# Altium schematic sheet Interfaces.SchDoc(Interfaces)
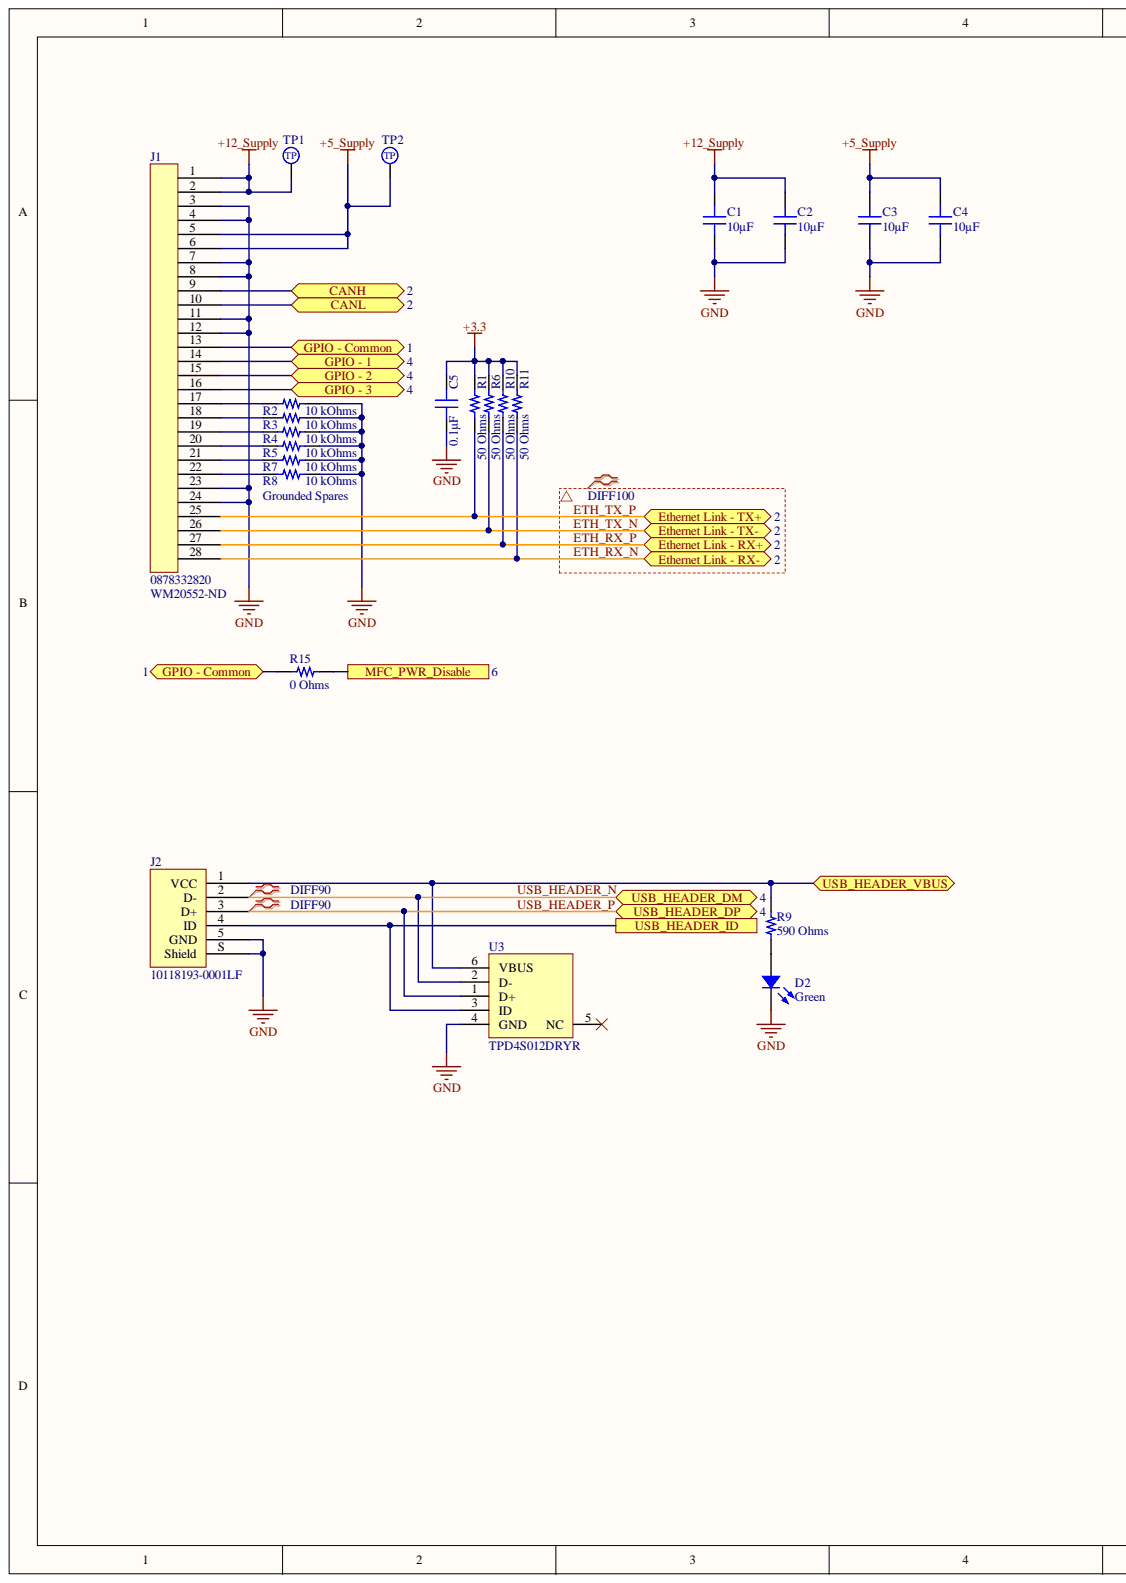
[diagram p0: Interfaces.SchDoc, left]
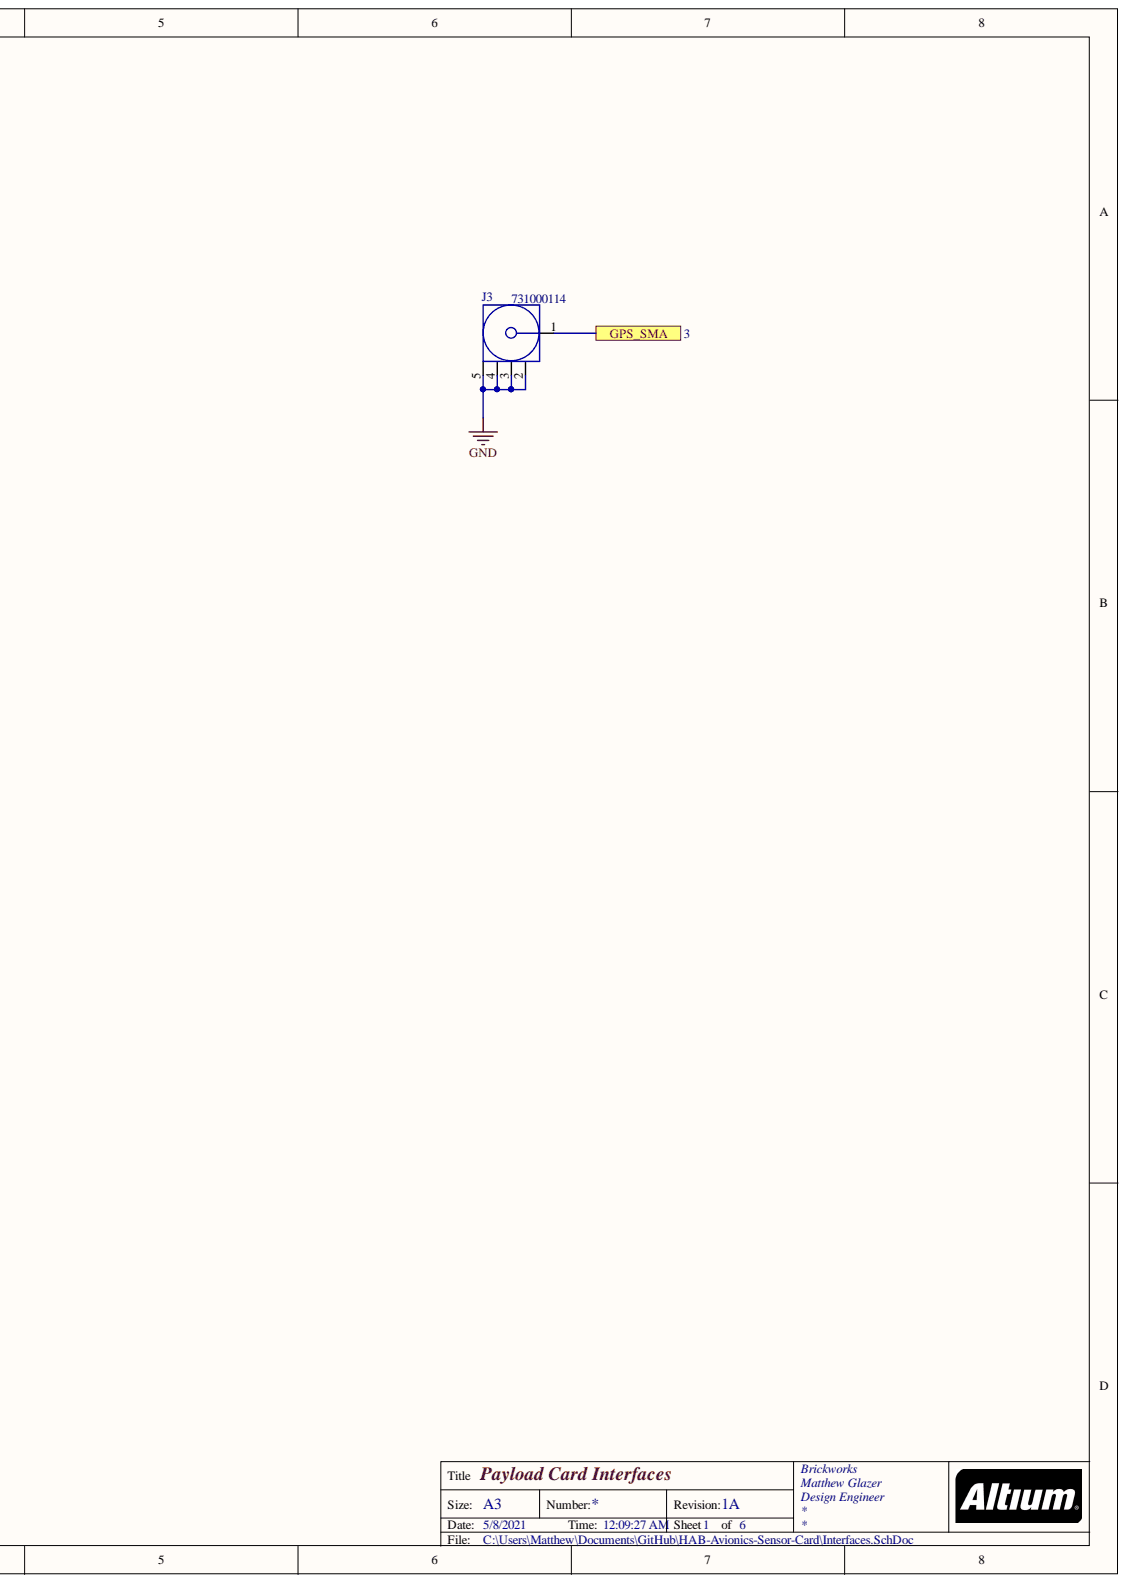
[diagram p1: Interfaces.SchDoc, right]

## Parts
C1  10µF  [CAP 0805_2012]
C2  10µF  [CAP 0805_2012]
C3  10µF  [CAP 0805_2012]
C4  10µF  [CAP 0805_2012]
C5  0.1µF  [CAP 0603_1608]
D2  Green  [LED 0603_1608 GREEN]
J1  0878332820  Supplier Part Number 1: WM20552-ND  [0878332820]
J2  10118193-0001LF  [FCI USB 10118193-0001LF]
J3  731000114  [MOLX-731000114_V]
R1  50 Ohms  [RES 0603_1608]
R2  10 kOhms  [RES 0603_1608]
R3  10 kOhms  [RES 0603_1608]
R4  10 kOhms  [RES 0603_1608]
R5  10 kOhms  [RES 0603_1608]
R6  50 Ohms  [RES 0603_1608]
R7  10 kOhms  [RES 0603_1608]
R8  10 kOhms  [RES 0603_1608]
R9  590 Ohms  [RES 0603_1608]
R10  50 Ohms  [RES 0603_1608]
R11  50 Ohms  [RES 0603_1608]
R15  0 Ohms  [RES 0603_1608]
TP1  2.5mm_SMD_TestPoint  [2.5mm TestPoint]  (value not drawn)
TP2  2.5mm_SMD_TestPoint  [2.5mm TestPoint]  (value not drawn)
U3  TPD4S012DRYR  [DRY0006A]

## Ports
CANH, CANL, Ethernet Link - RX+, Ethernet Link - RX-, Ethernet Link - TX+, Ethernet Link - TX-, GPIO - 1, GPIO - 2, GPIO - 3, GPIO - Common, GPS_SMA, MFC_PWR_Disable, USB_HEADER_DM, USB_HEADER_DP, USB_HEADER_ID, USB_HEADER_VBUS

## Nets
+3.3: C5.2 R1.2 R6.2 R10.2 R11.2
+5_Supply: C3.2 C4.2 J1.5 J1.6 TP2.1
+12_Supply: C1.2 C2.2 J1.1 J1.2 TP1.1
GND: C1.1 C2.1 C3.1 C4.1 C5.1 D2.2 J1.3 J1.4 J1.7 J1.8 J1.11 J1.12 J1.23 J1.24 J2.5(GND) J2.S(Shield) J3.2 J3.3 J3.4 J3.5 R2.2 R3.2 R4.2 R5.2 R7.2 R8.2 U3.4(GND)
CANH: J1.9 ; port CANH
CANL: J1.10 ; port CANL
ETH_RX_N: J1.28 R11.1
ETH_RX_P: J1.27 R10.1
ETH_TX_N: J1.26 R6.1
ETH_TX_P: J1.25 R1.1
GPIO - 1: J1.14 ; port GPIO - 1
GPIO - 2: J1.15 ; port GPIO - 2
GPIO - 3: J1.16 ; port GPIO - 3
GPIO - Common: J1.13 R15.1 ; port GPIO - Common
GPS_SMA: J3.1 ; port GPS_SMA
MFC_PWR_Disable: R15.2 ; port MFC_PWR_Disable
USB_HEADER_ID: J2.4(ID) U3.3(ID) ; port USB_HEADER_ID
USB_HEADER_N: J2.2(D-) U3.2(D-) ; port USB_HEADER_DM
USB_HEADER_P: J2.3(D+) U3.1(D+) ; port USB_HEADER_DP
USB_HEADER_VBUS: J2.1(VCC) R9.2 U3.6(VBUS) ; port USB_HEADER_VBUS
NetD2_1: D2.1 R9.1
NetJ1_17: J1.17 R2.1
NetJ1_18: J1.18 R3.1
NetJ1_19: J1.19 R4.1
NetJ1_20: J1.20 R5.1
NetJ1_21: J1.21 R7.1
NetJ1_22: J1.22 R8.1
N00023:

Not connected: U3 5(NC)

## Library data of the parts
C1-C4 = CC0805KKX5R7BB106: CAP CER 10UF 16V X5R 0805; Manufacturer: Yageo; Tolerance: ±10%; Database Table Name: Capacitors - Ceramic - 0805; Voltage - Rated: 16V; Value: 10µF; Thickness (Max): 0.057" (1.45mm); Temperature Coefficient: X5R; Supplier Part Number 1: 311-1865-1-ND; Supplier 1: DigiKey; Size / Dimension: 0.079" L x 0.049" W (2.00mm x 1.25mm); Series: CC; Price: 0.09; Part Status: Active; Part Number: CC0805KKX5R7BB106; PartId: 8867; Packaging: TapeAndReel; Package / Case: 0805 (2012 Metric); Operating Temperature: -55°C ~ 85°C; Mounting Type: Surface Mount, MLCC; Minimum Order: 1; Lifecycle Status: Active; Library Name: Celestial Altium Library - altium_library.DbLib; LastUpdated: 9/2/2020 1:04:30 AM; Device Package: 0805 (2012 Metric); ComponentLink2URL: http://digikey.com/product-detail/en/yageo/CC0805KKX5R7BB106/311-1865-1-ND/5195767; ComponentLink2Description: DigiKey Link; ComponentLink1URL: https://www.yageo.com/upload/media/product/productsearch/datasheet/mlcc/UPY-GPHC_X5R_4V-to-50V_25.pdf; ComponentLink1Description: Datasheet; Component Kind: Standard; Capacitance: 10µF; Applications: General Purpose
C5 = CL10B104KA8WPNC: CAP CER 0.1UF 25V X7R 0603; Manufacturer: Samsung Electro-Mechanics; Tolerance: ±10%; Database Table Name: Capacitors - Ceramic - 0603; Voltage - Rated: 25V; Value: 0.1µF; Thickness (Max): 0.035" (0.90mm); Temperature Coefficient: X7R; Supplier Part Number 1: 1276-6717-1-ND; Supplier 1: DigiKey; Size / Dimension: 0.063" L x 0.031" W (1.60mm x 0.80mm); Series: CL; Ratings: AEC-Q200; Price: 0.02; Part Status: Active; Part Number: CL10B104KA8WPNC; PartId: 5631; Packaging: TapeAndReel; Package / Case: 0603 (1608 Metric); Operating Temperature: -55°C ~ 125°C; Mounting Type: Surface Mount, MLCC; Minimum Order: 1; Lifecycle Status: Active; Library Name: Celestial Altium Library - altium_library.DbLib; LastUpdated: 9/2/2020 1:03:30 AM; Features: Open Mode; Device Package: 0603 (1608 Metric); ComponentLink2URL: http://digikey.com/product-detail/en/samsung-electro-mechanics/CL10B104KA8WPNC/1276-6717-1-ND/5961576; ComponentLink2Description: DigiKey Link; ComponentLink1URL: http://www.samsungsem.com/kr/support/product-search/mlcc/CL10B104KA8WPNC.jsp; ComponentLink1Description: Datasheet; Component Kind: Standard; Capacitance: 0.1µF; Applications: Automotive
D2 = LTST-C193KGKT-5A: LED GREEN CLEAR CHIP SMD; Manufacturer: Lite-On Inc.; Wavelength - Peak: 574nm; Wavelength - Dominant: 572nm; Voltage - Forward (Vf) (Typ): 2V; Viewing Angle: 130°; Millicandela Rating: 16.25mcd; Lens Style: Rectangle with Flat Top; Lens Size: 1.15mm x 0.80mm; Lens Color: Colorless; Database Table Name: LED - Indication; Current - Test: 5mA; Configuration: Standard; Value: Green; Supplier Part Number 1: 160-1828-1-ND; Supplier Device Package: Chip LED; Supplier 1: DigiKey; Size / Dimension: 1.60mm L x 0.80mm W; Series: -; Price: 0.06; Part Status: Active; Part Number: LTST-C193KGKT-5A; PartId: 694; Packaging: TapeAndReel; Package / Case: 0603 (1608 Metric); Mounting Type: Surface Mount; Minimum Order: 1; Lifecycle Status: Active; Library Name: Celestial Altium Library - altium_library.DbLib; Lens Transparency: Clear; LastUpdated: 9/2/2020 1:20:41 AM; Height (Max): 0.45mm; Device Package: 0603 (1608 Metric); ComponentLink2URL: http://digikey.com/product-detail/en/lite-on-inc/LTST-C193KGKT-5A/160-1828-1-ND/2356247; ComponentLink2Description: DigiKey Link; ComponentLink1URL: http://optoelectronics.liteon.com/upload/download/DS22-2007-0094/LTST-C193KGKT-5A.PDF; ComponentLink1Description: Datasheet; Component Kind: Standard; Color: Green
J2 = 10118193-0001LF: CONN RCPT USB2.0 MICRO B SMD R/A; Manufacturer: Amphenol ICC (FCI); Voltage - Rated: 100VAC; Shielding: Shielded; Number of Ports: 1; Number of Contacts: 5; Mating Cycles: 10000; Gender: Receptacle; Database Table Name: Connectors - USB DVI HDMI; Current Rating (Amps): 1.8A per Contact; Connector Type: USB - micro B; Termination: Solder; Supplier Part Number 1: 609-4616-2-ND; Supplier 1: DigiKey; Specifications: USB 2.0; Series: -; Price: 0.21; Part Status: Active; Part Number: 10118193-0001LF; Packaging: TapeAndReel; Operating Temperature: -30°C ~ 80°C; Mounting Type: Surface Mount, Right Angle; Through Hole; Mounting Feature: Horizontal; Minimum Order: 1; Lifecycle Status: 0; Library Name: Celestial Altium Library - altium_library.DbLib; LastUpdated: 12/9/2019 8:51:28 PM; Features: Solder Retention; ComponentLink2URL: //digikey.com/product-detail/en/amphenol-icc-fci/10118193-0001LF/609-4616-2-ND/2785388; ComponentLink2Description: DigiKey Link; ComponentLink1URL: https://cdn.amphenol-icc.com/media/wysiwyg/files/documentation/datasheet/inputoutput/io_usb_micro.pdf; ComponentLink1Description: Datasheet; Component Kind: Standard
J3 = CMP-2000-05487-1: SMA Right-Angle PCB Jack Receptacle, Brass Body, Gold Plated, 50 Ohm, 5-Pin THD, RoHS, Tray; Manufacturer: Molex; Package URL: http://www.molex.com/pdm_docs/sd/731000114_sd.pdf; Package Reference: 731000114; Package Description: 5-Pin Through Hole Device, Body 14.8 x 6.35 mm, Pitch 2.54 mm; Mounting Technology: ThroughHole; Manufacturer URL: http://www.molex.com/; Library Name: Altium Content Vault; Datasheet Version: 09/2016; Datasheet URL: http://www.molex.com/webdocs/datasheets/pdf/en-us/0731000114_RF_COAX_CONNECTORS.pdf; Component Kind: Standard
R1 R6 R10 R11 = CRCW060350R0FKEA: RES SMD 50 OHM 1% 1/10W 0603; Manufacturer: Vishay Dale; Temperature Coefficient: ±100ppm/°C; Power (Watts): 0.1W, 1/10W; Database Table Name: Resistors - Surface Mount - 0603; Value: 50 Ohms; Tolerance: ±1%; Supplier Part Number 1: 541-3318-1-ND; Supplier Device Package: 0603; Supplier 1: DigiKey; Size / Dimension: 0.061" L x 0.033" W (1.55mm x 0.85mm); Series: CRCW; Resistance: 50 Ohms; Ratings: AEC-Q200; Price: 0.10; Part Status: Active; Part Number: CRCW060350R0FKEA; PartId: 23780; Packaging: CutTape; Package / Case: 0603 (1608 Metric); Operating Temperature: -55°C ~ 155°C; Number of Terminations: 2; Minimum Order: 1; Lifecycle Status: Active; Library Name: Celestial Altium Library - altium_library.DbLib; LastUpdated: 9/2/2020 1:09:40 AM; Height - Seated (Max): 0.020" (0.50mm); Features: Automotive AEC-Q200; Device Package: 0603 (1608 Metric); Composition: Thick Film; ComponentLink2URL: http://digikey.com/product-detail/en/vishay-dale/CRCW060350R0FKEA/541-3318-1-ND/6684924; ComponentLink2Description: DigiKey Link; ComponentLink1URL: http://www.vishay.com/docs/20035/dcrcwe3.pdf; ComponentLink1Description: Datasheet; Component Kind: Standard
R2-R5 R7 R8 = RMCF0603JT10K0: RES 10K OHM 5% 1/10W 0603; Manufacturer: Stackpole Electronics Inc; Temperature Coefficient: ±200ppm/°C; Power (Watts): 0.1W, 1/10W; Database Table Name: Resistors - Surface Mount - 0603; Value: 10 kOhms; Tolerance: ±5%; Supplier Part Number 1: RMCF0603JT10K0CT-ND; Supplier Device Package: 0603; Supplier 1: DigiKey; Size / Dimension: 0.061" L x 0.031" W (1.55mm x 0.80mm); Series: RMCF; Resistance: 10 kOhms; Ratings: AEC-Q200; Price: 0.10; Part Status: Active; Part Number: RMCF0603JT10K0; PartId: 25659; Packaging: CutTape; Package / Case: 0603 (1608 Metric); Operating Temperature: -55°C ~ 155°C; Number of Terminations: 2; Minimum Order: 1; Lifecycle Status: Active; Library Name: Celestial Altium Library - altium_library.DbLib; LastUpdated: 8/30/2020 8:48:45 PM; Height - Seated (Max): 0.022" (0.55mm); Features: Automotive AEC-Q200; Device Package: 0603 (1608 Metric); Composition: Thick Film; ComponentLink2URL: http://digikey.com/product-detail/en/stackpole-electronics-inc/RMCF0603JT10K0/RMCF0603JT10K0CT-ND/1943191; ComponentLink2Description: DigiKey Link; ComponentLink1URL: https://www.seielect.com/catalog/sei-packaging.pdf; ComponentLink1Description: Datasheet; Component Kind: Standard
R9 = RMCF0603FT590R: RES 590 OHM 1% 1/10W 0603; Manufacturer: Stackpole Electronics Inc; Temperature Coefficient: ±100ppm/°C; Power (Watts): 0.1W, 1/10W; Database Table Name: Resistors - Surface Mount - 0603; Value: 590 Ohms; Tolerance: ±1%; Supplier Part Number 1: RMCF0603FT590RCT-ND; Supplier Device Package: 0603; Supplier 1: DigiKey; Size / Dimension: 0.061" L x 0.031" W (1.55mm x 0.80mm); Series: RMCF; Resistance: 590 Ohms; Ratings: AEC-Q200; Price: 0.10; Part Status: Active; Part Number: RMCF0603FT590R; PartId: 23847; Packaging: CutTape; Package / Case: 0603 (1608 Metric); Operating Temperature: -55°C ~ 155°C; Number of Terminations: 2; Minimum Order: 1; Lifecycle Status: Active; Library Name: Celestial Altium Library - altium_library.DbLib; LastUpdated: 9/2/2020 1:09:40 AM; Height - Seated (Max): 0.022" (0.55mm); Features: Automotive AEC-Q200; Device Package: 0603 (1608 Metric); Composition: Thick Film; ComponentLink2URL: http://digikey.com/product-detail/en/stackpole-electronics-inc/RMCF0603FT590R/RMCF0603FT590RCT-ND/2418096; ComponentLink2Description: DigiKey Link; ComponentLink1URL: https://www.seielect.com/catalog/sei-rmcf_rmcp.pdf; ComponentLink1Description: Datasheet; Component Kind: Standard
R15 = RMCF0603ZT0R00: RES 0 OHM JUMPER 1/10W 0603; Manufacturer: Stackpole Electronics Inc; Power (Watts): 0.1W, 1/10W; Database Table Name: Resistors - Surface Mount - 0603; Value: 0 Ohms; Tolerance: Jumper; Supplier Part Number 1: RMCF0603ZT0R00CT-ND; Supplier Device Package: 0603; Supplier 1: DigiKey; Size / Dimension: 0.061" L x 0.031" W (1.55mm x 0.80mm); Series: RMCF; Resistance: 0 Ohms; Ratings: AEC-Q200; Price: 0.10; Part Status: Active; Part Number: RMCF0603ZT0R00; PartId: 22613; Packaging: CutTape; Package / Case: 0603 (1608 Metric); Operating Temperature: -55°C ~ 155°C; Number of Terminations: 2; Minimum Order: 1; Lifecycle Status: Active; Library Name: Celestial Altium Library - altium_library.DbLib; LastUpdated: 9/2/2020 1:09:45 AM; Height - Seated (Max): 0.022" (0.55mm); Features: Automotive AEC-Q200; Device Package: 0603 (1608 Metric); Composition: Thick Film; ComponentLink2URL: http://digikey.com/product-detail/en/stackpole-electronics-inc/RMCF0603ZT0R00/RMCF0603ZT0R00CT-ND/1943218; ComponentLink2Description: DigiKey Link; ComponentLink1URL: https://www.seielect.com/catalog/sei-rmcf_rmcp.pdf; ComponentLink1Description: Datasheet; Component Kind: Standard
U3 = CMP-0326-00038-3: USB ESD Solution with Power Clamp, 4 Channels, -40 to +85 degC, 6-pin SON (DRY), Green (RoHS & no Sb/Br); Manufacturer: Texas Instruments; USB High Speed: TRUE; IO Leakage Current(nA): 10; IO Capacitance(Typ)(pF): 0.8; Breakdown Voltage(Min)(V): 6; SubFamily: ESD Solution; PartNumber: TPD4S012DRYR; PackageVersion: revF, Dec-2011; PackageReference: DRY0006A; PackageDescription: 6-Pin Plastic Small Outline No-Lead, 1 x 1.45 x 0.6 mm Body, 0.5 mm Pitch; Mounting Technology: Surface Mount; Library Name: Altium Content Vault; IEC 61000-4-2 Contact(+/- kV): 10; IEC 61000-4-2 Air-Gap(+/- kV): 10; Eco-Plan: Green (RoHS & no Sb/Br); DatasheetVersion: SLVS928A; ComponentLink3URL: SamplesURL:http://www.ti.com/product/tpd4s012#samples; ComponentLink3Description: URL Link1; ComponentLink2URL: http://www.ti.com/general/docs/lit/getliterature.tsp?genericPartNumber=TPD4S012&fileType=pdf; ComponentLink2Description: Datasheet; ComponentLink1URL: http://www.ti.com/; ComponentLink1Description: Manufacturer URL; Component Kind: Standard
J1 = 0878332820: Supplier 1: Digi-Key; Component Kind: Standard; Library Name: HAB_Connectors.SchLib
TP1 TP2 = 2.5mm_SMD_TestPoint: Component Kind: Standard; Library Name: HAB_Components.IntLib
